# Altium schematic sheet main.SchDoc
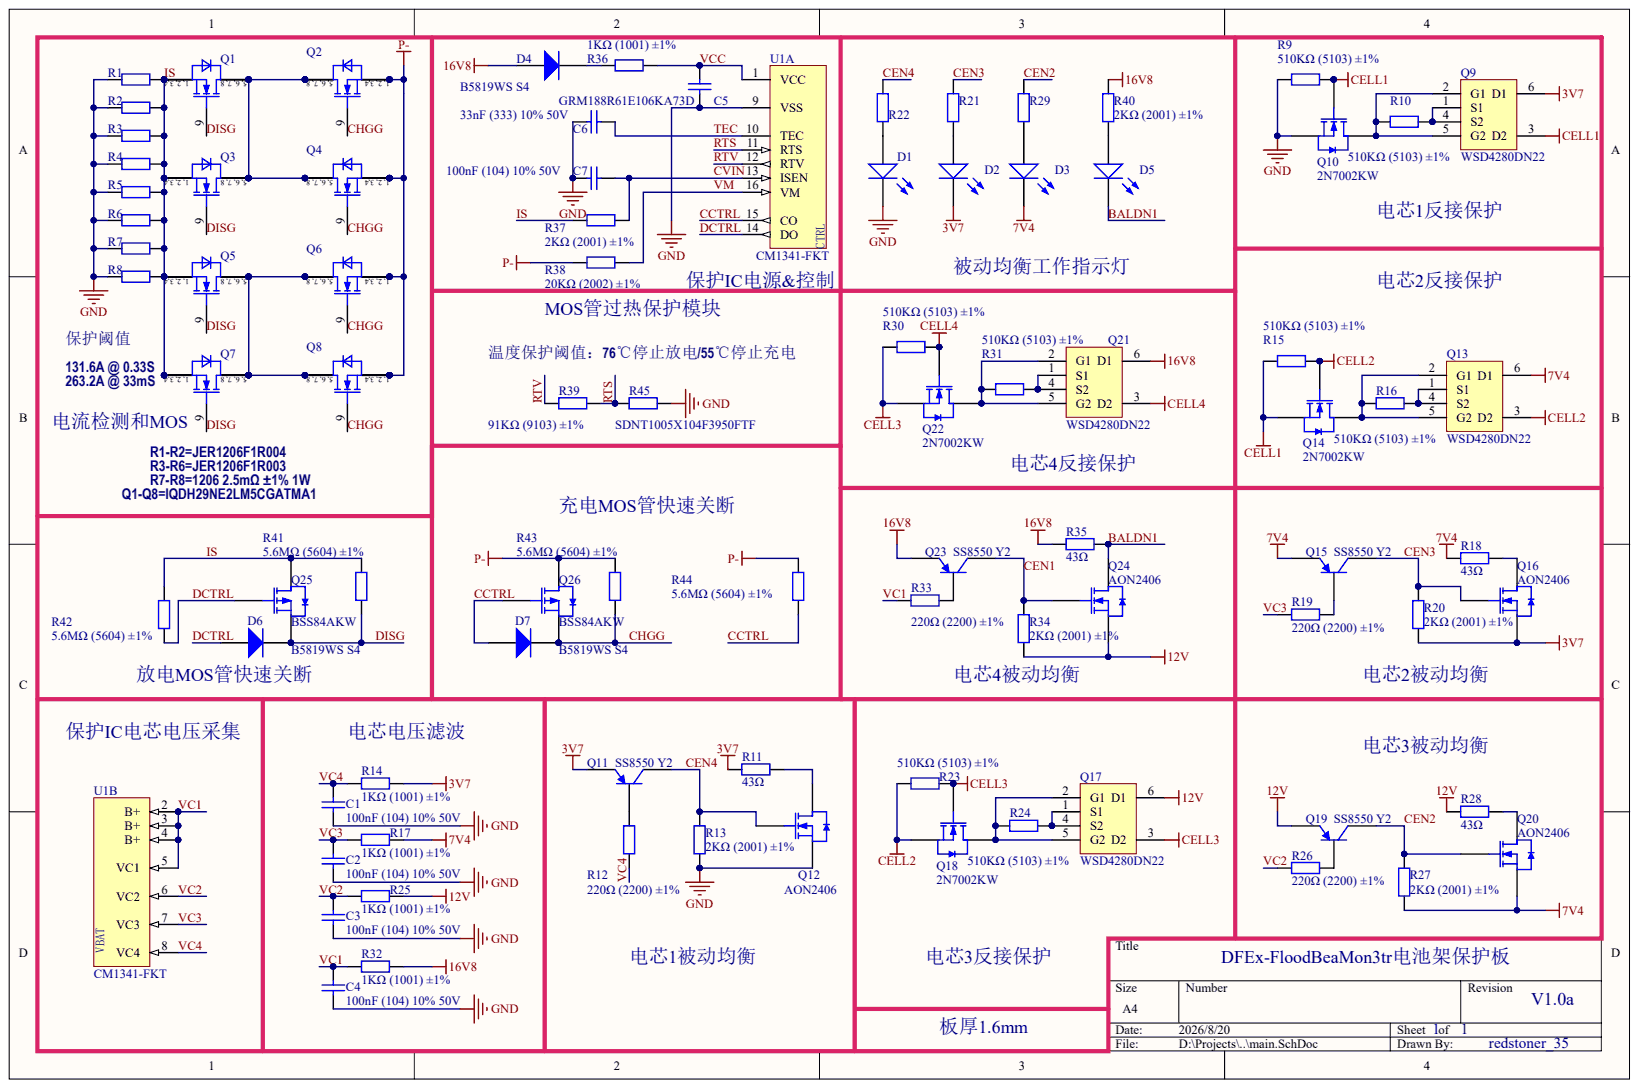
[diagram p0: main.SchDoc]

## Parts
C1  100nF (104) 10% 50V  [0402_C]
C2  100nF (104) 10% 50V  [0402_C]
C3  100nF (104) 10% 50V  [0402_C]
C4  100nF (104) 10% 50V  [0402_C]
C5  GRM188R61E106KA73D  [0603_C]
C6  33nF (333) 10% 50V  [0402_C]
C7  100nF (104) 10% 50V  [0402_C]
D1  白灯 0603  [0603_LED_S1]  (value not drawn)
D2  白灯 0603  [0603_LED_S1]  (value not drawn)
D3  白灯 0603  [0603_LED_S1]  (value not drawn)
D4  B5819WS S4  [SOD-323]
D5  白灯 0603  [0603_LED_S1]  (value not drawn)
D6  B5819WS S4  [SOD-323]
D7  B5819WS S4  [SOD-323]
Q1  IQDH29NE2LM5CG  [IFX-PG-WHTFN-9-2-V]
Q2  IQDH29NE2LM5CG  [IFX-PG-WHTFN-9-2-V]
Q3  IQDH29NE2LM5CG  [IFX-PG-WHTFN-9-2-V]
Q4  IQDH29NE2LM5CG  [IFX-PG-WHTFN-9-2-V]
Q5  IQDH29NE2LM5CG  [IFX-PG-WHTFN-9-2-V]
Q6  IQDH29NE2LM5CG  [IFX-PG-WHTFN-9-2-V]
Q7  IQDH29NE2LM5CG  [IFX-PG-WHTFN-9-2-V]
Q8  IQDH29NE2LM5CG  [IFX-PG-WHTFN-9-2-V]
Q9  WSD4280DN22  [UDFN-6_L2.0-W2.0-P0.65-BL_NTLUD3A50PZ]
Q10  2N7002KW  [SC70-3]
Q11  SS8550 Y2  [SC70-3]
Q12  AON2406  [PDFN-6_L2.0-W2.0-P0.65-BL-EP]
Q13  WSD4280DN22  [UDFN-6_L2.0-W2.0-P0.65-BL_NTLUD3A50PZ]
Q14  2N7002KW  [SC70-3]
Q15  SS8550 Y2  [SC70-3]
Q16  AON2406  [PDFN-6_L2.0-W2.0-P0.65-BL-EP]
Q17  WSD4280DN22  [UDFN-6_L2.0-W2.0-P0.65-BL_NTLUD3A50PZ]
Q18  2N7002KW  [SC70-3]
Q19  SS8550 Y2  [SC70-3]
Q20  AON2406  [PDFN-6_L2.0-W2.0-P0.65-BL-EP]
Q21  WSD4280DN22  [UDFN-6_L2.0-W2.0-P0.65-BL_NTLUD3A50PZ]
Q22  2N7002KW  [SC70-3]
Q23  SS8550 Y2  [SC70-3]
Q24  AON2406  [PDFN-6_L2.0-W2.0-P0.65-BL-EP]
Q25  BSS84AKW  [SC70-3]
Q26  BSS84AKW  [SC70-3]
R1  JER1206F1R004  [1206R]
R2  JER1206F1R004  [1206R]
R3  JER1206F1R003  [1206R]
R4  JER1206F1R003  [1206R]
R5  JER1206F1R003  [1206R]
R6  JER1206F1R003  [1206R]
R7  1206 2.5mΩ ±1% 1W  [1206R]
R8  1206 2.5mΩ ±1% 1W  [1206R]
R9  510KΩ (5103) ±1%  [0402_R]
R10  510KΩ (5103) ±1%  [0402_R]
R11  43Ω  [1210R]
R12  220Ω (2200) ±1%  [0402_R]
R13  2KΩ (2001) ±1%  [0402_R]
R14  1KΩ (1001) ±1%  [0402_R]
R15  510KΩ (5103) ±1%  [0402_R]
R16  510KΩ (5103) ±1%  [0402_R]
R17  1KΩ (1001) ±1%  [0402_R]
R18  43Ω  [1210R]
R19  220Ω (2200) ±1%  [0402_R]
R20  2KΩ (2001) ±1%  [0402_R]
R21  2KΩ (2001) ±1%  [0402_R]  (value not drawn)
R22  2KΩ (2001) ±1%  [0402_R]  (value not drawn)
R23  510KΩ (5103) ±1%  [0402_R]
R24  510KΩ (5103) ±1%  [0402_R]
R25  1KΩ (1001) ±1%  [0402_R]
R26  220Ω (2200) ±1%  [0402_R]
R27  2KΩ (2001) ±1%  [0402_R]
R28  43Ω  [1210R]
R29  2KΩ (2001) ±1%  [0402_R]  (value not drawn)
R30  510KΩ (5103) ±1%  [0402_R]
R31  510KΩ (5103) ±1%  [0402_R]
R32  1KΩ (1001) ±1%  [0402_R]
R33  220Ω (2200) ±1%  [0402_R]
R34  2KΩ (2001) ±1%  [0402_R]
R35  43Ω  [1210R]
R36  1KΩ (1001) ±1%  [0402_R]
R37  2KΩ (2001) ±1%  [0402_R]
R38  20KΩ (2002) ±1%  [0402_R]
R39  91KΩ (9103) ±1%  [0402_R]
R40  2KΩ (2001) ±1%  [0402_R]
R41  5.6MΩ (5604) ±1%  [0402_R]
R42  5.6MΩ (5604) ±1%  [0402_R]
R43  5.6MΩ (5604) ±1%  [0402_R]
R44  5.6MΩ (5604) ±1%  [0402_R]
R45  SDNT1005X104F3950FTF  [0402_R]
U1  CM1341-FKT  [TSSOP16-CM1341]  (parts A,B)

## Nets
3V7: D2.K Q9.6(D1) Q11.2 Q16.2 R11.2 R14.2 R20.1
7V4: D3.K Q13.6(D1) Q15.2 Q20.2 R17.2 R18.2 R27.1
12V: Q17.6(D1) Q19.2 Q24.2 R25.2 R28.2 R34.1
16V8: D4.1 Q21.6(D1) Q23.2 R32.2 R35.2 R40.2
CELL1: Q9.3(D2) Q10.1 Q14.2 R9.1 R15.1
CELL2: Q13.3(D2) Q14.1 Q18.2 R15.2 R23.1
CELL3: Q17.3(D2) Q18.1 Q22.2 R23.2 R30.1
CELL4: Q21.3(D2) Q22.1 R30.2
GND: C1.1 C2.1 C3.1 C4.1 C5.1 C6.1 C7.1 D1.K Q10.2 Q12.2 R1.2 R2.2 R3.2 R4.2 R5.2 R6.2 R7.2 R8.2 R9.2 R13.1 R45.1 U1.9(VSS)
P-: Q2.1 Q2.2 Q2.3 Q2.4 Q4.1 Q4.2 Q4.3 Q4.4 Q6.1 Q6.2 Q6.3 Q6.4 Q8.1 Q8.2 Q8.3 Q8.4 Q26.3 R38.1 R43.2 R44.2
BALDN1: D5.K Q24.3 R35.1
CCTRL: D7.1 Q26.1 R44.1 U1.15(CO)
CEN1: Q23.3 Q24.1 R34.2
CEN2: Q19.3 Q20.1 R27.2 R29.2
CEN3: Q15.3 Q16.1 R20.2 R21.2
CEN4: Q11.3 Q12.1 R13.2 R22.2
CHGG: D7.2 Q2.9 Q4.9 Q6.9 Q8.9 Q26.2 R43.1
CVIN: C7.2 R37.2 U1.13(ISEN)
DCTRL: D6.1 Q25.1 R42.1 U1.14(DO)
DISG: D6.2 Q1.9 Q3.9 Q5.9 Q7.9 Q25.2 R41.1
IS: Q1.1 Q1.2 Q1.3 Q1.4 Q3.1 Q3.2 Q3.3 Q3.4 Q5.1 Q5.2 Q5.3 Q5.4 Q7.1 Q7.2 Q7.3 Q7.4 Q25.3 R1.1 R2.1 R3.1 R4.1 R5.1 R6.1 R7.1 R8.1 R37.1 R41.2 R42.2
RTS: R39.1 R45.2 U1.11(RTS)
RTV: R39.2 U1.12(RTV)
TEC: C6.2 U1.10(TEC)
VC1: C4.2 R32.1 R33.1 U1.2(B+) U1.3(B+) U1.4(B+) U1.5(VC1)
VC2: C3.2 R25.1 R26.1 U1.6(VC2)
VC3: C2.2 R17.1 R19.1 U1.7(VC3)
VC4: C1.2 R12.1 R14.1 U1.8(VC4)
VCC: C5.2 R36.2 U1.1(VCC)
VM: R38.2 U1.16(VM)
NetD1_A: D1.A R22.1
NetD2_A: D2.A R21.1
NetD3_A: D3.A R29.1
NetD4_2: D4.2 R36.1
NetD5_A: D5.A R40.1
NetQ1_5: Q1.5 Q1.6 Q1.7 Q1.8 Q2.5 Q2.6 Q2.7 Q2.8 Q3.5 Q3.6 Q3.7 Q3.8 Q4.5 Q4.6 Q4.7 Q4.8
NetQ5_5: Q5.5 Q5.6 Q5.7 Q5.8 Q6.5 Q6.6 Q6.7 Q6.8 Q7.5 Q7.6 Q7.7 Q7.8 Q8.5 Q8.6 Q8.7 Q8.8
NetQ9_1: Q9.1(S1) Q9.4(S2) R10.1
NetQ9_2: Q9.2(G1) Q9.5(G2) Q10.3 R10.2
NetQ11_1: Q11.1 R12.2
NetQ12_3: Q12.3 R11.1
NetQ13_1: Q13.1(S1) Q13.4(S2) R16.2
NetQ13_2: Q13.2(G1) Q13.5(G2) Q14.3 R16.1
NetQ15_1: Q15.1 R19.2
NetQ16_3: Q16.3 R18.1
NetQ17_1: Q17.1(S1) Q17.4(S2) R24.2
NetQ17_2: Q17.2(G1) Q17.5(G2) Q18.3 R24.1
NetQ19_1: Q19.1 R26.2
NetQ20_3: Q20.3 R28.1
NetQ21_1: Q21.1(S1) Q21.4(S2) R31.2
NetQ21_2: Q21.2(G1) Q21.5(G2) Q22.3 R31.1
NetQ23_1: Q23.1 R33.2

## Library data of the parts
C1-C4 = 0402 220nF (224) 10% 16V: 贴片电容; Component Kind: Standard; ComponentLink1Description: 供应商链接; ComponentLink1URL: http://www.szlcsc.com/product/details_17456.html; Library Name: Miscellaneous Devices LC.IntLib; Package: 0402; Supplier: LC; SuppliersPartNumber: C16772
C5 = 0603 10uF (106) 10% 10V: 0603贴片MLCC电容 10uF ±20% 25V X5R; Component Kind: Standard; ComponentLink1Description: 供应商链接; ComponentLink1URL: http://www.szlcsc.com/product/details_20411.html; Library Name: Miscellaneous Devices LC.IntLib; Package: 0603; Supplier: LC; SuppliersPartNumber: C19702
C6 C7 = 0402 100nF (104) 10% 16V: 贴片电容; Component Kind: Standard; ComponentLink1Description: 供应商链接; ComponentLink1URL: http://www.szlcsc.com/product/details_1877.html; Library Name: Miscellaneous Devices LC.IntLib; Package: 0402; Supplier: LC; SuppliersPartNumber: C1525
D1-D3 D5 = 0603 白灯: 发光二极管; Component Kind: Standard; ComponentLink1Description: 供应商链接; ComponentLink1URL: http://www.szlcsc.com/product/details_2663.html; Library Name: Miscellaneous Devices LC.IntLib; Package: 0603; Supplier: LC; SuppliersPartNumber: C2290
D4 D6 D7 = SOD-323 1N4148WS T4: 二极管; Component Kind: Standard; ComponentLink1Description: 供应商链接; ComponentLink1URL: http://www.szlcsc.com/product/details_2485.html; Library Name: Miscellaneous Devices LC.IntLib; Package: SOD-323; Supplier: LC; SuppliersPartNumber: C2128
Q1-Q8 = IQDH29NE2LM5CGSC: 英飞凌MOSFET 25V 789A 0.2mΩ @ 10V WHTFN-9(PDFN5x6源极底置)封装; Manufacturer: Infineon Technologies; Component Kind: Standard; Library Name: custom.SchLib; Manufacturer Order Number: IQDH29NE2LM5CGSC; PackageReference: PG-WHTFN-9-2
Q9 Q13 Q17 Q21 = NTLUD3A50PZ: 内置肖特基二极管的双P沟道MOS -15V -4.6A 45mΩ @ 4.5V DFN2x2封装; Component Kind: Standard; Library Name: custom.SchLib
Q10 Q14 Q18 Q22 = 2N7002 7002: 单N沟道MOSFET(场效应管); Component Kind: Standard; ComponentLink1Description: 供应商链接; ComponentLink1URL: http://www.szlcsc.com/product/details_9040.html; Library Name: Miscellaneous Devices LC.IntLib; Package: SOT-323; Supplier: LC; SuppliersPartNumber: C???????
Q11 Q15 Q19 Q23 = SOT-23 SS8550 Y2: PNP三极管，SOT-323 封装; Component Kind: Standard; ComponentLink1Description: 供应商链接; ComponentLink1URL: http://www.szlcsc.com/product/details_9037.html; Library Name: Miscellaneous Devices LC.IntLib; Package: SOT-23; Supplier: LC; SuppliersPartNumber: C8542
Q12 Q16 Q20 Q24 = AO3400A N道沟 X0YV1T SOT-23: MOS(场效应管); Component Kind: Standard; ComponentLink1Description: 供应商链接; ComponentLink1URL: http://www.szlcsc.com/product/details_21629.html; Library Name: Miscellaneous Devices LC.IntLib; Package: SOT-23; Supplier: LC; SuppliersPartNumber: C20917
Q25 Q26 = SI2301CDS-T1-GE3: 单P沟道小信号MOSFET(场效应管); Component Kind: Standard; ComponentLink1Description: 供应商链接; ComponentLink1URL: http://www.szlcsc.com/product/details_11030.html; Library Name: Miscellaneous Devices LC.IntLib; Package: SOT-23(SOT-23-3); Supplier: LC; SuppliersPartNumber: C10487
R1 R2 = Res: 贴片合金电流检测电阻 1206封装 4mΩ ±1% 1W; Component Kind: Standard; LatestRevisionDate: 17-Jul-2002; LatestRevisionNote: Re-released for DXP Platform.; PackageDescription: Axial Device, Thru-Hole; 2 Leads; 0.4 in Pin Spacing; PackageReference: AXIAL-0.4; Published: 8-Jun-2000; Value: 4mΩ; Library Name: 山猫的集成库20190318.IntLib; Publisher: Altium Limited
R3-R6 = Res: 贴片合金电流检测电阻 1206封装 3mΩ ±1% 1W; Component Kind: Standard; LatestRevisionDate: 17-Jul-2002; LatestRevisionNote: Re-released for DXP Platform.; PackageDescription: Axial Device, Thru-Hole; 2 Leads; 0.4 in Pin Spacing; PackageReference: AXIAL-0.4; Published: 8-Jun-2000; Value: 3mΩ; Library Name: 山猫的集成库20190318.IntLib; Publisher: Altium Limited
R7 R8 = Res: 贴片合金检流电阻 1206 2.5mΩ ±1% 1W; Component Kind: Standard; LatestRevisionDate: 17-Jul-2002; LatestRevisionNote: Re-released for DXP Platform.; PackageDescription: Axial Device, Thru-Hole; 2 Leads; 0.4 in Pin Spacing; PackageReference: AXIAL-0.4; Published: 8-Jun-2000; Value: 2.5mΩ; Library Name: 山猫的集成库20190318.IntLib; Publisher: Altium Limited
R9 R10 R15 R16 R23 R24 R30 R31 = 0402 510KΩ (5103) 1%: 贴片电阻; Component Kind: Standard; ComponentLink1Description: 供应商链接; ComponentLink1URL: http://www.szlcsc.com/product/details_12170.html; Library Name: Miscellaneous Devices LC.IntLib; Package: 0402; Supplier: LC; SuppliersPartNumber: C11616
R11 R18 R28 R35 = Res: Resistor; Component Kind: Standard; LatestRevisionDate: 17-Jul-2002; LatestRevisionNote: Re-released for DXP Platform.; PackageDescription: Axial Device, Thru-Hole; 2 Leads; 0.4 in Pin Spacing; PackageReference: AXIAL-0.4; Published: 8-Jun-2000; Value: 43Ω; Library Name: 山猫的集成库20190318.IntLib; Publisher: Altium Limited
R12 R19 R26 R33 = 0402 220Ω (2200) 1%: 贴片电阻; Component Kind: Standard; ComponentLink1Description: 供应商链接; ComponentLink1URL: http://www.szlcsc.com/product/details_25834.html; Library Name: Miscellaneous Devices LC.IntLib; Package: 0402; Supplier: LC; SuppliersPartNumber: C25091
R13 R20-R22 R27 R29 R34 R37 R40 = 0402 2KΩ (2001) 1%: 贴片电阻; Component Kind: Standard; ComponentLink1Description: 供应商链接; ComponentLink1URL: http://www.szlcsc.com/product/details_4516.html; Library Name: Miscellaneous Devices LC.IntLib; Package: 0402; Supplier: LC; SuppliersPartNumber: C4109
R14 R17 R25 R32 R36 = 0402 1KΩ (1001) 1%: 贴片电阻; Component Kind: Standard; ComponentLink1Description: 供应商链接; ComponentLink1URL: http://www.szlcsc.com/product/details_12256.html; Library Name: Miscellaneous Devices LC.IntLib; Package: 0402; Supplier: LC; SuppliersPartNumber: C11702
R38 = 0402 20KΩ (2002) 1%: 贴片电阻; Component Kind: Standard; ComponentLink1Description: 供应商链接; ComponentLink1URL: http://www.szlcsc.com/product/details_26508.html; Library Name: Miscellaneous Devices LC.IntLib; Package: 0402; Supplier: LC; SuppliersPartNumber: C25765
R39 = 0402 100KΩ (1003) 1%: 贴片电阻; Component Kind: Standard; ComponentLink1Description: 供应商链接; ComponentLink1URL: http://www.szlcsc.com/product/details_26484.html; Library Name: Miscellaneous Devices LC.IntLib; Package: 0402; Supplier: LC; SuppliersPartNumber: C25741
R41-R44 = 0402 1MΩ (1004) 1%: 贴片电阻; Component Kind: Standard; ComponentLink1Description: 供应商链接; ComponentLink1URL: http://www.szlcsc.com/product/details_26826.html; Library Name: Miscellaneous Devices LC.IntLib; Package: 0402; Supplier: LC; SuppliersPartNumber: C26083
R45 = 0402 100KΩ (1003) 1%: 100KΩ (1003) ±1% B3950 NTC片式贴片热敏电阻; Component Kind: Standard; ComponentLink1Description: 供应商链接; ComponentLink1URL: http://www.szlcsc.com/product/details_26484.html; Library Name: Miscellaneous Devices LC.IntLib; Package: 0402; Supplier: LC; SuppliersPartNumber: C25741
U1 = CM1341-FKT: 4串三元锂电池带均衡功能保护IC，SSOP16封装。; Component Kind: Standard; Library Name: custom.SchLib
